# Altium schematic sheet Controle.SchDoc
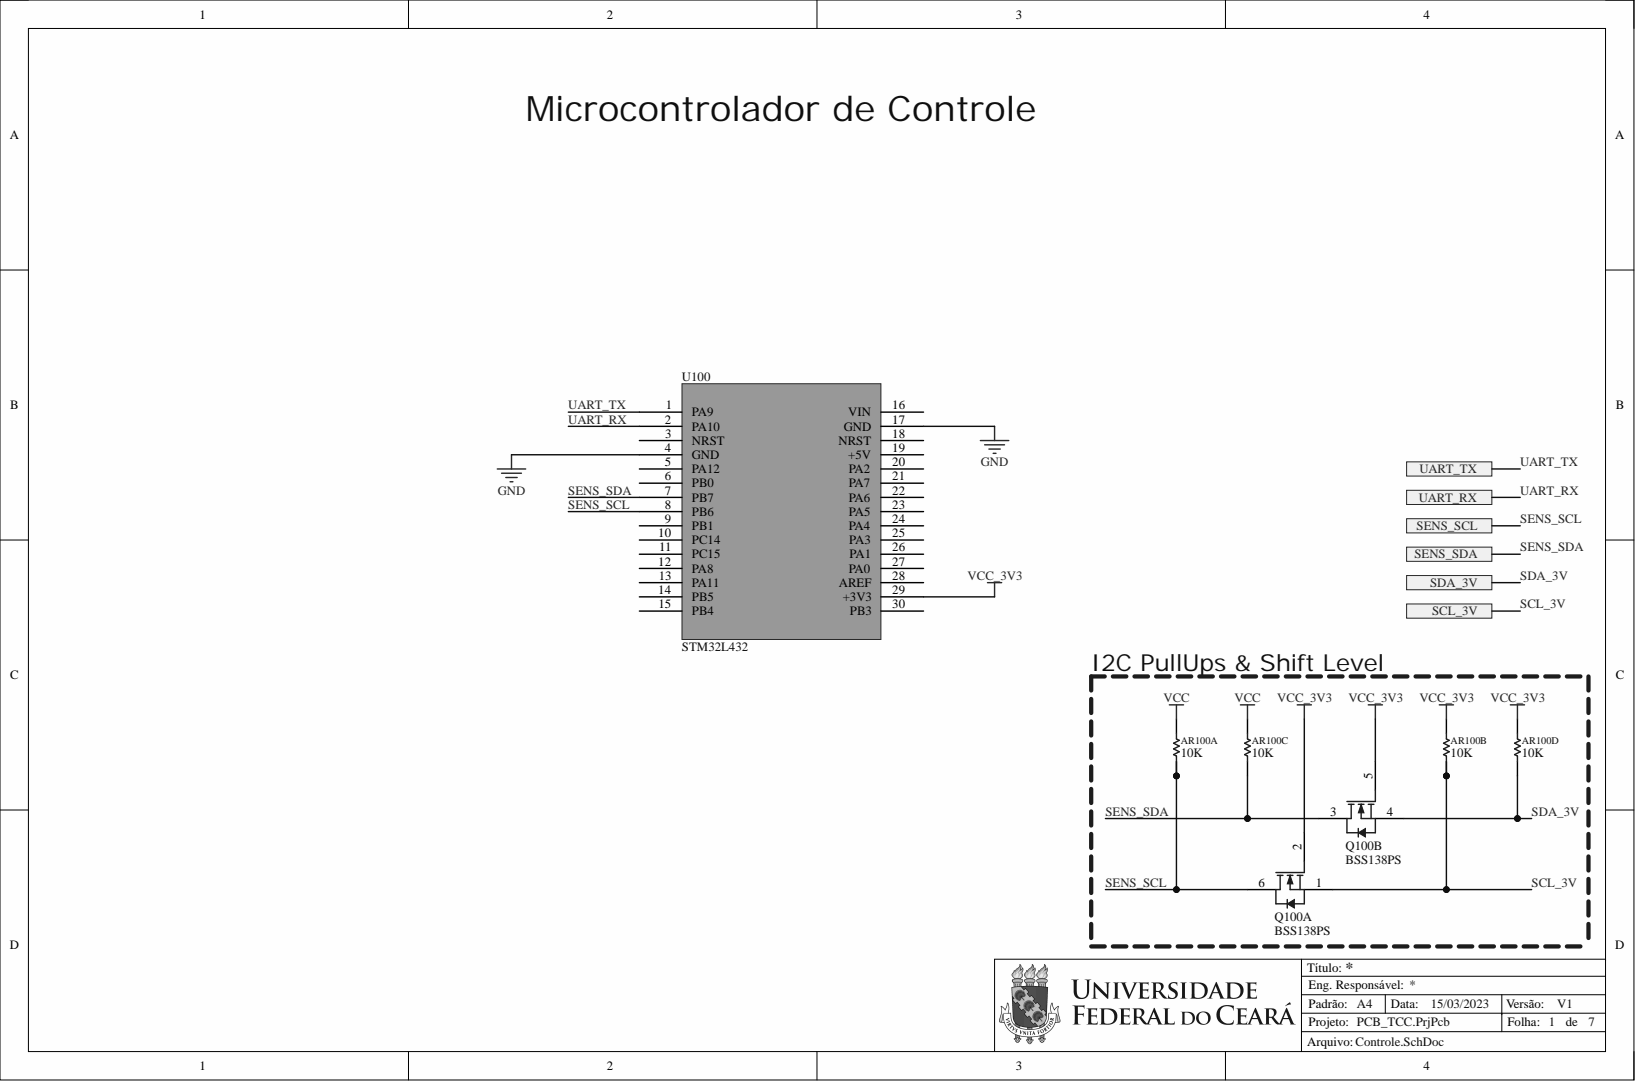
[diagram p0: Controle.SchDoc]

## Parts
AR100  10K  [RES_ARRAY _4RES_1206]  (parts A,B,C,D)
Q100  BSS138PS  [BSS138PS]  (parts A,B)
U100  STM32L432  [STM32L432]

## Ports
SCL_3V, SDA_3V, SENS_SCL, SENS_SDA, UART_RX, UART_TX

## Nets
GND: U100.4(GND) U100.17(GND)
VCC: AR100.6 AR100.8
VCC_3V3: AR100.5 AR100.7 Q100.2 Q100.5 U100.29(+3V3)
SCL_3V: AR100.2 Q100.1 ; port SCL_3V
SDA_3V: AR100.4 Q100.4 ; port SDA_3V
SENS_SCL: AR100.1 Q100.6 U100.8(PB6) ; port SENS_SCL
SENS_SDA: AR100.3 Q100.3 U100.7(PB7) ; port SENS_SDA
UART_RX: U100.2(PA10) ; port UART_RX
UART_TX: U100.1(PA9) ; port UART_TX

Not connected: U100 3(NRST) 5(PA12) 6(PB0) 9(PB1) 10(PC14) 11(PC15) 12(PA8) 13(PA11) 14(PB5) 15(PB4) 16(VIN) 18(NRST) 19(+5V) 20(PA2) 21(PA7) 22(PA6) 23(PA5) 24(PA4) 25(PA3) 26(PA1) 27(PA0) 28(AREF) 30(PB3)

## Library data of the parts
AR100 = RES_ARRAY_10K_1206: Component Kind: Standard; Supplier 1: Arrow Electronics; Supplier Part Number 1: CAY16-103J4LF; Library Name: PCB_TCC.SchLib
Q100 = BSS138PS: Component Kind: Standard; Supplier 1: Arrow Electronics; Supplier Part Number 1: BSS138PS,115; Library Name: PCB_TCC.SchLib
U100 = STM32L432: Component Kind: Standard; Library Name: PCB_TCC.SchLib
